# Altium schematic sheet ICS.SchDoc
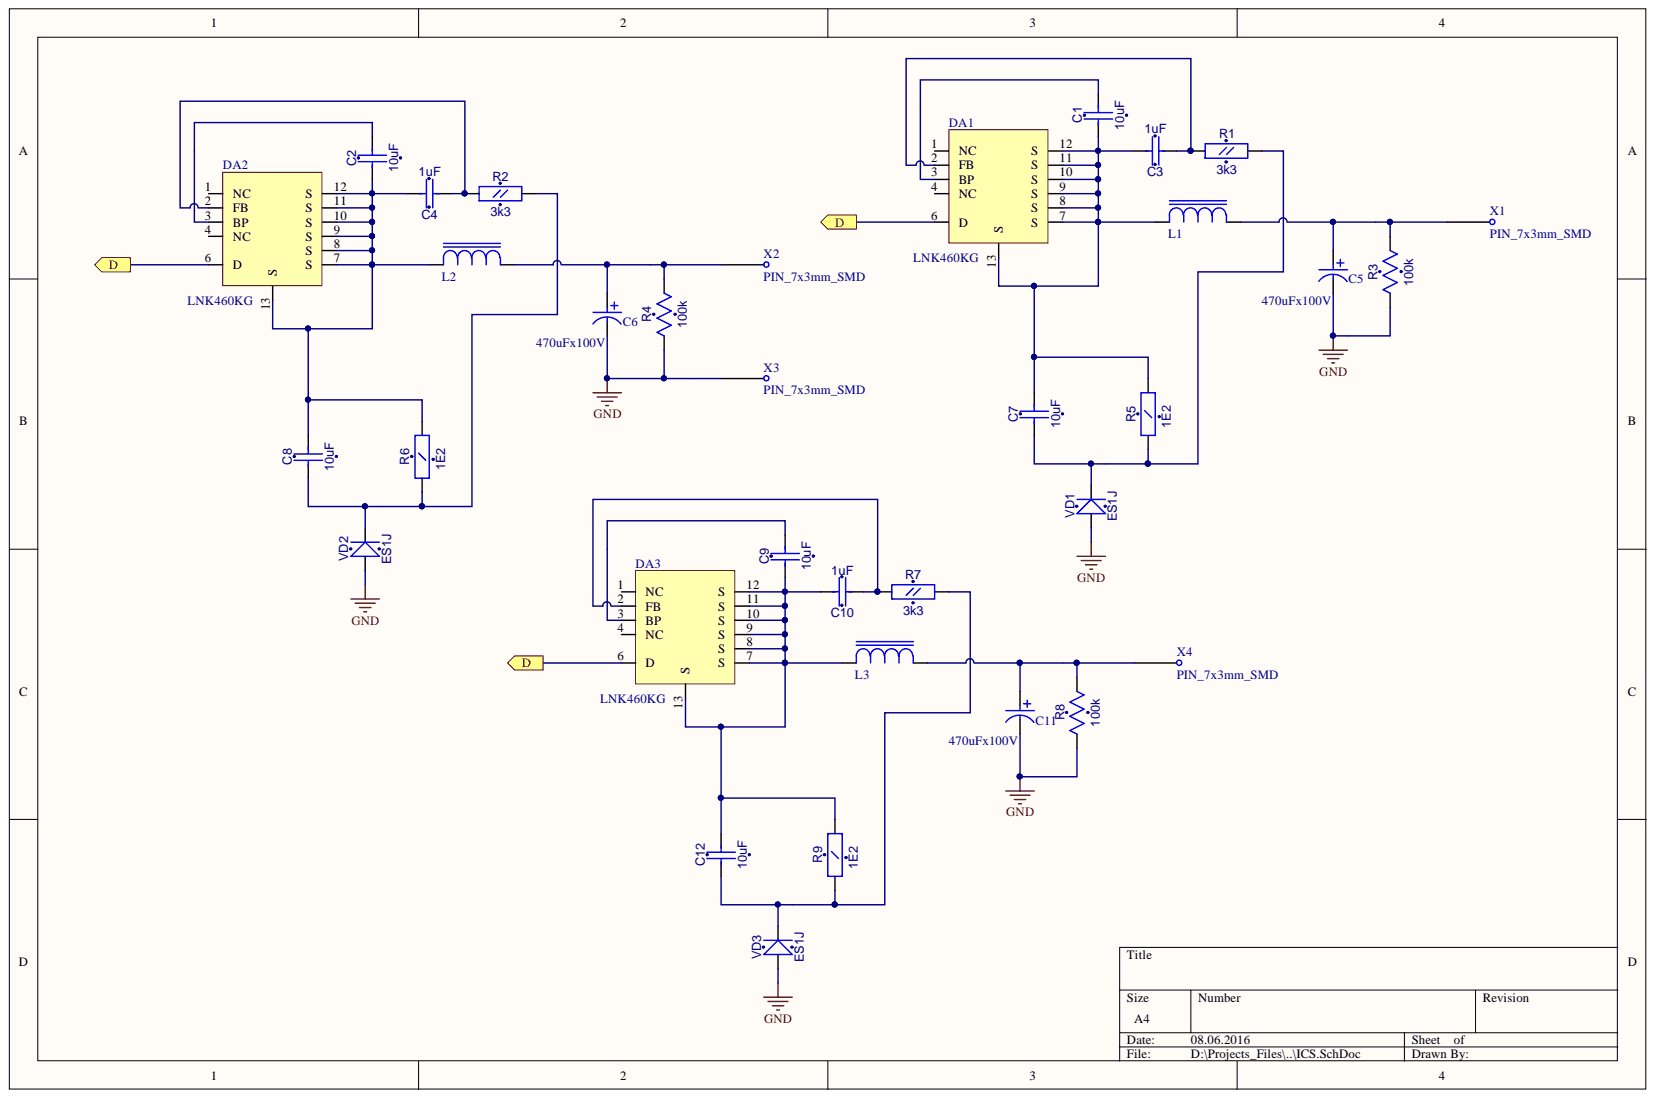
[diagram p0: ICS.SchDoc]

## Parts
C1  10uF  [CC1206]
C2  10uF  [CC1206]
C3  1uF  [CC0805]
C4  1uF  [CC0805]
C5  470uFx100V  [CAP_7.5_SMD_2]
C6  470uFx100V  [CAP_7.5_SMD_2]
C7  10uF  [CC1206]
C8  10uF  [CC1206]
C9  10uF  [CC1206]
C10  1uF  [CC0805]
C11  470uFx100V  [CAP_7.5_SMD_2]
C12  10uF  [CC1206]
DA1  LNK460KG  [eSOP-12]
DA2  LNK460KG  [eSOP-12]
DA3  LNK460KG  [eSOP-12]
L1  CDRH129HF-331MC  [IND_CDRH129]  (value not drawn)
L2  CDRH129HF-331MC  [IND_CDRH129]  (value not drawn)
L3  CDRH129HF-331MC  [IND_CDRH129]  (value not drawn)
R1  3k3  [RC0805]
R2  3k3  [RC0805]
R3  100k  [RC0805]
R4  100k  [RC0805]
R5  1E2  [RC1206]
R6  1E2  [RC1206]
R7  3k3  [RC0805]
R8  100k  [RC0805]
R9  1E2  [RC1206]
VD1  ES1J  [SMA]
VD2  ES1J  [SMA]
VD3  ES1J  [SMA]
X1  PIN_7x3mm_SMD  [PIN_7x3mm_SMD]
X2  PIN_7x3mm_SMD  [PIN_7x3mm_SMD]
X3  PIN_7x3mm_SMD  [PIN_7x3mm_SMD]
X4  PIN_7x3mm_SMD  [PIN_7x3mm_SMD]

## Ports
D

## Nets
GND: C5.1 C6.1 C11.1 R3.1 R4.1 R8.1 VD1.1 VD2.1 VD3.1 X3.1
D: DA1.6(D) DA2.6(D) DA3.6(D) ; port D
NetC1_1: C1.1 C3.2 C7.2 DA1.7(S) DA1.8(S) DA1.9(S) DA1.10(S) DA1.11(S) DA1.12(S) DA1.13(S) L1.1 R5.2
NetC1_2: C1.2 DA1.3(BP)
NetC2_1: C2.1 C4.2 C8.2 DA2.7(S) DA2.8(S) DA2.9(S) DA2.10(S) DA2.11(S) DA2.12(S) DA2.13(S) L2.1 R6.2
NetC2_2: C2.2 DA2.3(BP)
NetC3_1: C3.1 DA1.2(FB) R1.1
NetC4_1: C4.1 DA2.2(FB) R2.1
NetC5_2: C5.2 L1.2 R3.2 X1.1
NetC6_2: C6.2 L2.2 R4.2 X2.1
NetC7_1: C7.1 R1.2 R5.1 VD1.2
NetC8_1: C8.1 R2.2 R6.1 VD2.2
NetC9_1: C9.1 C10.2 C12.2 DA3.7(S) DA3.8(S) DA3.9(S) DA3.10(S) DA3.11(S) DA3.12(S) DA3.13(S) L3.1 R9.2
NetC9_2: C9.2 DA3.3(BP)
NetC10_1: C10.1 DA3.2(FB) R7.1
NetC11_2: C11.2 L3.2 R8.2 X4.1
NetC12_1: C12.1 R7.2 R9.1 VD3.2

Not connected: DA1 1(NC) 4(NC); DA2 1(NC) 4(NC); DA3 1(NC) 4(NC)

## Library data of the parts
C1 C2 C7-C9 C12 = CC1206: Component Kind: Standard; Description: CC1206; Value: 10uF; Library Name: gprs_modem_2.SchLib
C3 C4 C10 = CC0805: Component Kind: Standard; Description: CC0805; Value: 1uF; Library Name: gprs_modem_2.SchLib
C5 C6 C11 = CAP_16x25: Component Kind: Standard; Value: 470uFx100V; Library Name: gprs_modem_2.SchLib
L1-L3 = CDRH129: Component Kind: Standard; Value: CDRH129HF-331MC; Library Name: gprs_modem_2.SchLib
R1-R4 R7 R8 = RC0805: Component Kind: Standard; Description: RC0805
  R1 R2 R7: Value: 3k3; Library Name: gprs_modem_2.SchLib
  R3 R4 R8: Value: 100k; Library Name: shlagbaum.SchLib
R5 R6 R9 = RC1206: Component Kind: Standard; Description: RC1206; Value: 1E2; Library Name: gprs_modem_2.SchLib
VD1-VD3 = SS14: Schottky Rectifier; Component Kind: Standard; Value: ES1J; Library Name: gprs_modem.SchLib
DA1-DA3 = LNK460KG: Component Kind: Standard; Value: LNK460KG; Library Name: gprs_modem.SchLib
X1-X4 = PIN_7x3mm_SMD: Component Kind: Standard; Library Name: gprs_modem.SchLib
